# Altium schematic sheet TP_STM32_UART.SchDoc
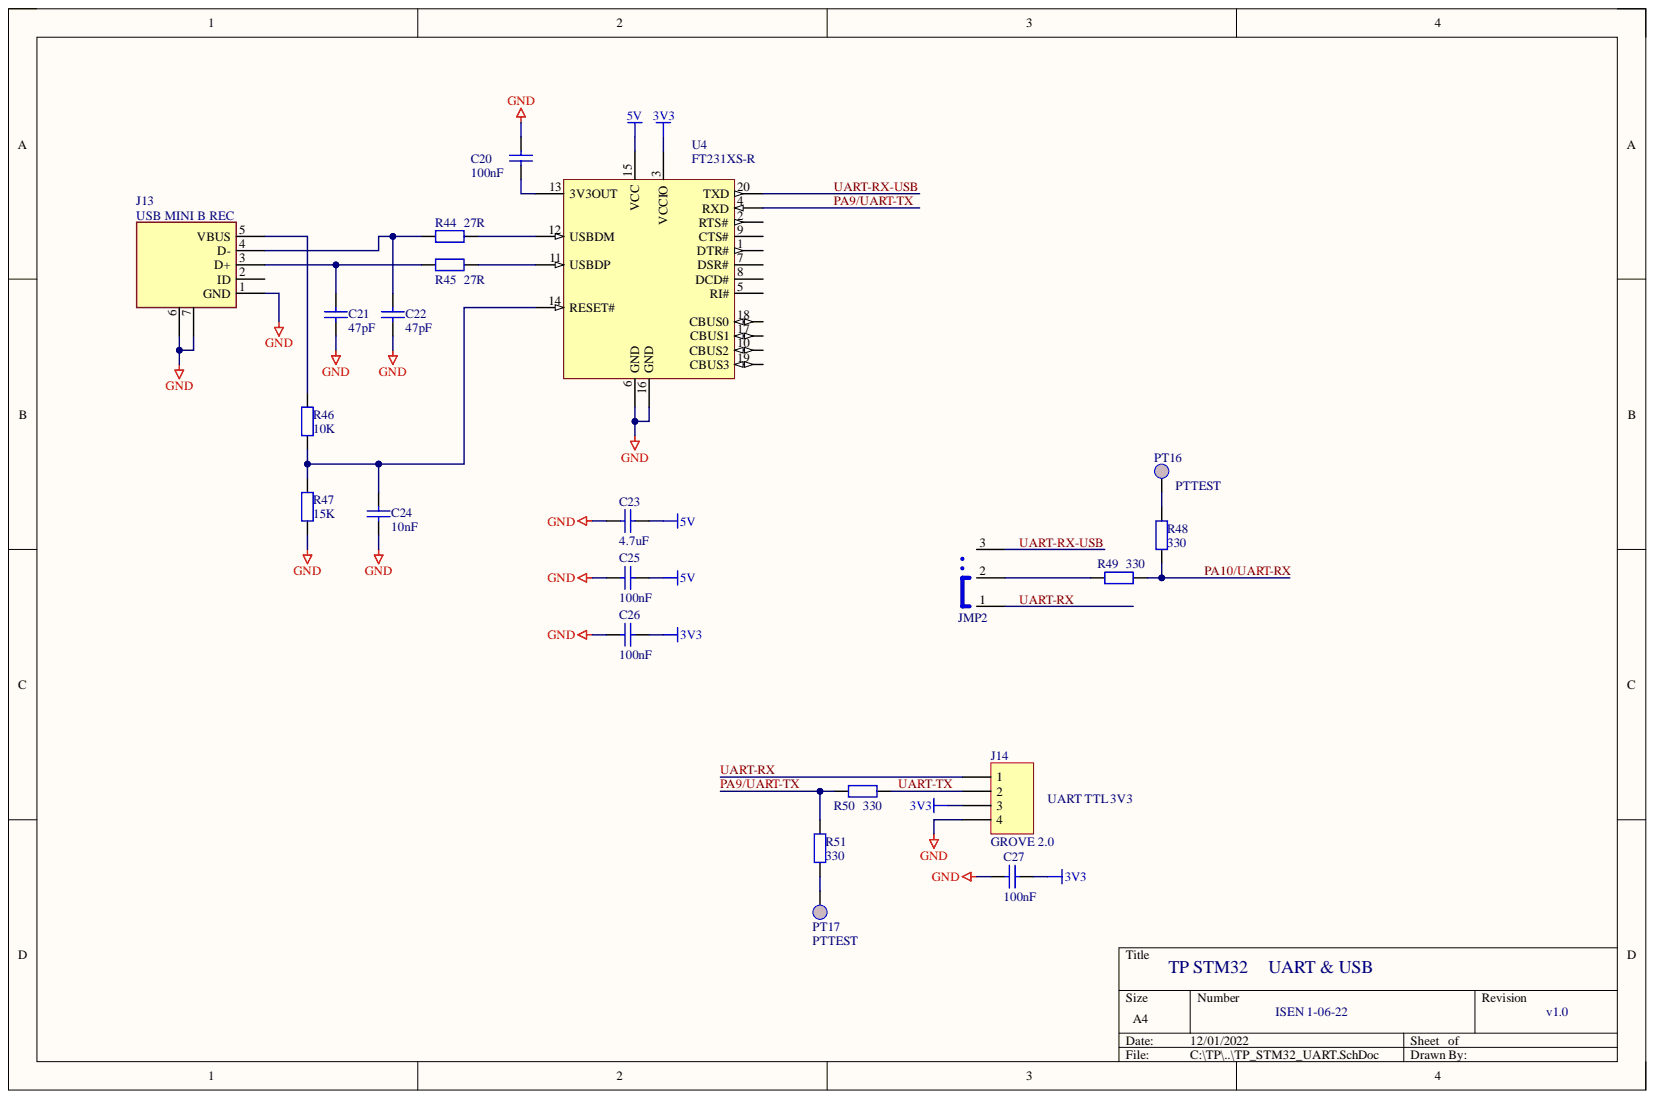
[diagram p0: TP_STM32_UART.SchDoc]

## Parts
C20  100nF  [CC2012-0805]
C21  47pF  [CC2012-0805]
C22  47pF  [CC2012-0805]
C23  4.7uF  [CC2012-0805]
C24  10nF  [CC2012-0805]
C25  100nF  [CC2012-0805]
C26  100nF  [CC2012-0805]
C27  100nF  [CC2012-0805]
J13  USB MINI B REC  [USB-MINI-B-REC]
J14  GROVE 2.0  [GROVE4-2.0]
JMP2  JUMPER-INV  [HDR1X3]  (value not drawn)
PT16  PTTEST  [PTEST]
PT17  PTTEST  [PTEST]
R44  27R  [R2012-0805]
R45  27R  [R2012-0805]
R46  10K  [R2012-0805]
R47  15K  [R2012-0805]
R48  330  [R2012-0805]
R49  330  [R2012-0805]
R50  330  [R2012-0805]
R51  330  [R2012-0805]
U4  FT231XS-R  [SSOP-20_N]

## Nets
3V3: C26.1 C27.1 J14.3 U4.3(VCCIO)
5V: C23.2 C25.1 U4.15(VCC)
GND: C20.2 C21.2 C22.2 C23.1 C24.2 C25.2 C26.2 C27.2 J13.1(GND) J13.6 J13.7 J14.4 R47.2 U4.6(GND) U4.16(GND)
PA9/UART-TX: R50.1 R51.2 U4.4(RXD)
PA10/UART-RX: R48.2 R49.2
UART-RX: J14.1 JMP2.1
UART-RX-USB: JMP2.3 U4.20(TXD)
UART-TX: J14.2 R50.2
NetC20_1: C20.1 U4.13(3V3OUT)
NetC21_1: C21.1 J13.3(D+) R45.1
NetC22_1: C22.1 J13.4(D-) R44.1
NetC24_1: C24.1 R46.2 R47.1 U4.14(RESET#)
NetJ13_5: J13.5(VBUS) R46.1
NetJMP2_2: JMP2.2 R49.1
NetPT16_1: PT16.1 R48.1
NetPT17_1: PT17.1 R51.1
NetR44_2: R44.2 U4.12(USBDM)
NetR45_2: R45.2 U4.11(USBDP)

Not connected: J13 2(ID); U4 1(DTR#) 2(RTS#) 5(RI#) 7(DSR#) 8(DCD#) 9(CTS#) 10(CBUS2) 17(CBUS1) 18(CBUS0) 19(CBUS3)

## Library data of the parts
C20 C24-C27 = Cap: Capacitor MLCC X7R 50V; Manufacturer: Generic Components; Class I: Passive; Class II: Capacitor; Component Kind: Standard; Library Name: Miscellaneous Devices.IntLib; Published: 8-Jun-2000; Revision: July-2002: Re-released for DXP Platform.; Signal Integrity: Cap; Simulation: CAP; Publisher: Altium Limited
  C20 C25-C27: Value: 100nF
  C24: Value: 10nF
C21 C22 = Cap: Capacitor MLCC COG 50V; Manufacturer: Generic Components; Class I: Passive; Class II: Capacitor; Component Kind: Standard; Library Name: Miscellaneous Devices.IntLib; Published: 8-Jun-2000; Revision: July-2002: Re-released for DXP Platform.; Signal Integrity: Cap; Simulation: CAP; Value: 47pF; Publisher: Altium Limited
C23 = Cap: Capacitor MLCC X5R 10V; Manufacturer: Generic Components; Class I: Passive; Class II: Capacitor; Component Kind: Standard; Library Name: Miscellaneous Devices.IntLib; Published: 8-Jun-2000; Revision: July-2002: Re-released for DXP Platform.; Signal Integrity: Cap; Simulation: CAP; Value: 4.7uF; Publisher: Altium Limited
J13 = CONUSB-MINI-B-REC: Connector USB receptacle mini B; Manufacturer: MOLEX; Manufacturer Part Number: 54819-0519; Component Kind: Standard; Height: 3mm; Library Name: Connector_v11.SchLib
J14 = MHDR1X4: Connector GROVE 4-Pin STRAIGHT pitch 2.0mm; Manufacturer: SEEED STUDIO; Component Kind: Standard; LatestRevisionDate: 17-Jul-2002; LatestRevisionNote: Re-released for DXP Platform.; Library Name: Miscellaneous Connectors.IntLib; Signal Integrity: Connector; Publisher: Altium Limited
JMP2 = JUMPER-INV: JUMPER INVERSEUR HE14 MALE 3 cts TE 5-826936-0; Component Kind: Standard; Manufacturer: TE; Library Name: oxy.SCHLIB
PT16 PT17 = POINT-TEST: POINT TEST VERO 20-2136; Class I: Passive; Class II: Resistor; Component Kind: Standard; Library Name: STM8S.SCHLIB; Manufacturer: VERO; Published: 15/12/03; Revision: 1.0; Publisher: ISEN BREST
R44-R51 = Res2: Resistor; Manufacturer: Generic Components; Class I: Passive; Class II: Resistor; Component Kind: Standard; Library Name: Miscellaneous Devices.IntLib; Published: 8-Jun-2000; Revision: July-2002: Re-released for DXP Platform.; Signal Integrity: Res2; Simulation: RESISTOR; Publisher: Altium Limited
  R44 R45: Value: 27R
  R46: Value: 10K
  R47: Value: 15K
  R48-R51: Value: 330
U4 = FT231XS-R: USB to Full-Handshake UART Interface Chip, UHCI/OHCI/EHCI Compatible, USB 2.0 Compatible, -40 to +85 degC, 20-Pin SSOP; Manufacturer: FTDI; Code_JEDEC: MO-137-AD; Component Kind: Standard; ComponentLink1Description: Manufacturer URL; ComponentLink1URL: http://www.ftdichip.com/; ComponentLink2Description: Datasheet; ComponentLink2URL: http://www.ftdichip.com/Support/Documents/DataSheets/ICs/DS_FT231X.pdf; ComponentLink3Description: FT231X Product Page; ComponentLink3URL: www.ftdichip.com/Products/ICs/FT231X.html; DatasheetVersion: ver 1.2, Feb-2013; Library Name: FTDI FT-X Series.IntLib; Manufacturer Part Number: FT231XS-R; PackageDescription: 20-Pin Small Outline Package, 8.6 x 3.9 mm Body, 0.635 mm Pitch; PackageReference: SSOP-20; PackageVersion: Feb-13
